# Altium schematic sheet Schematic Prints("All Documents",Physical)
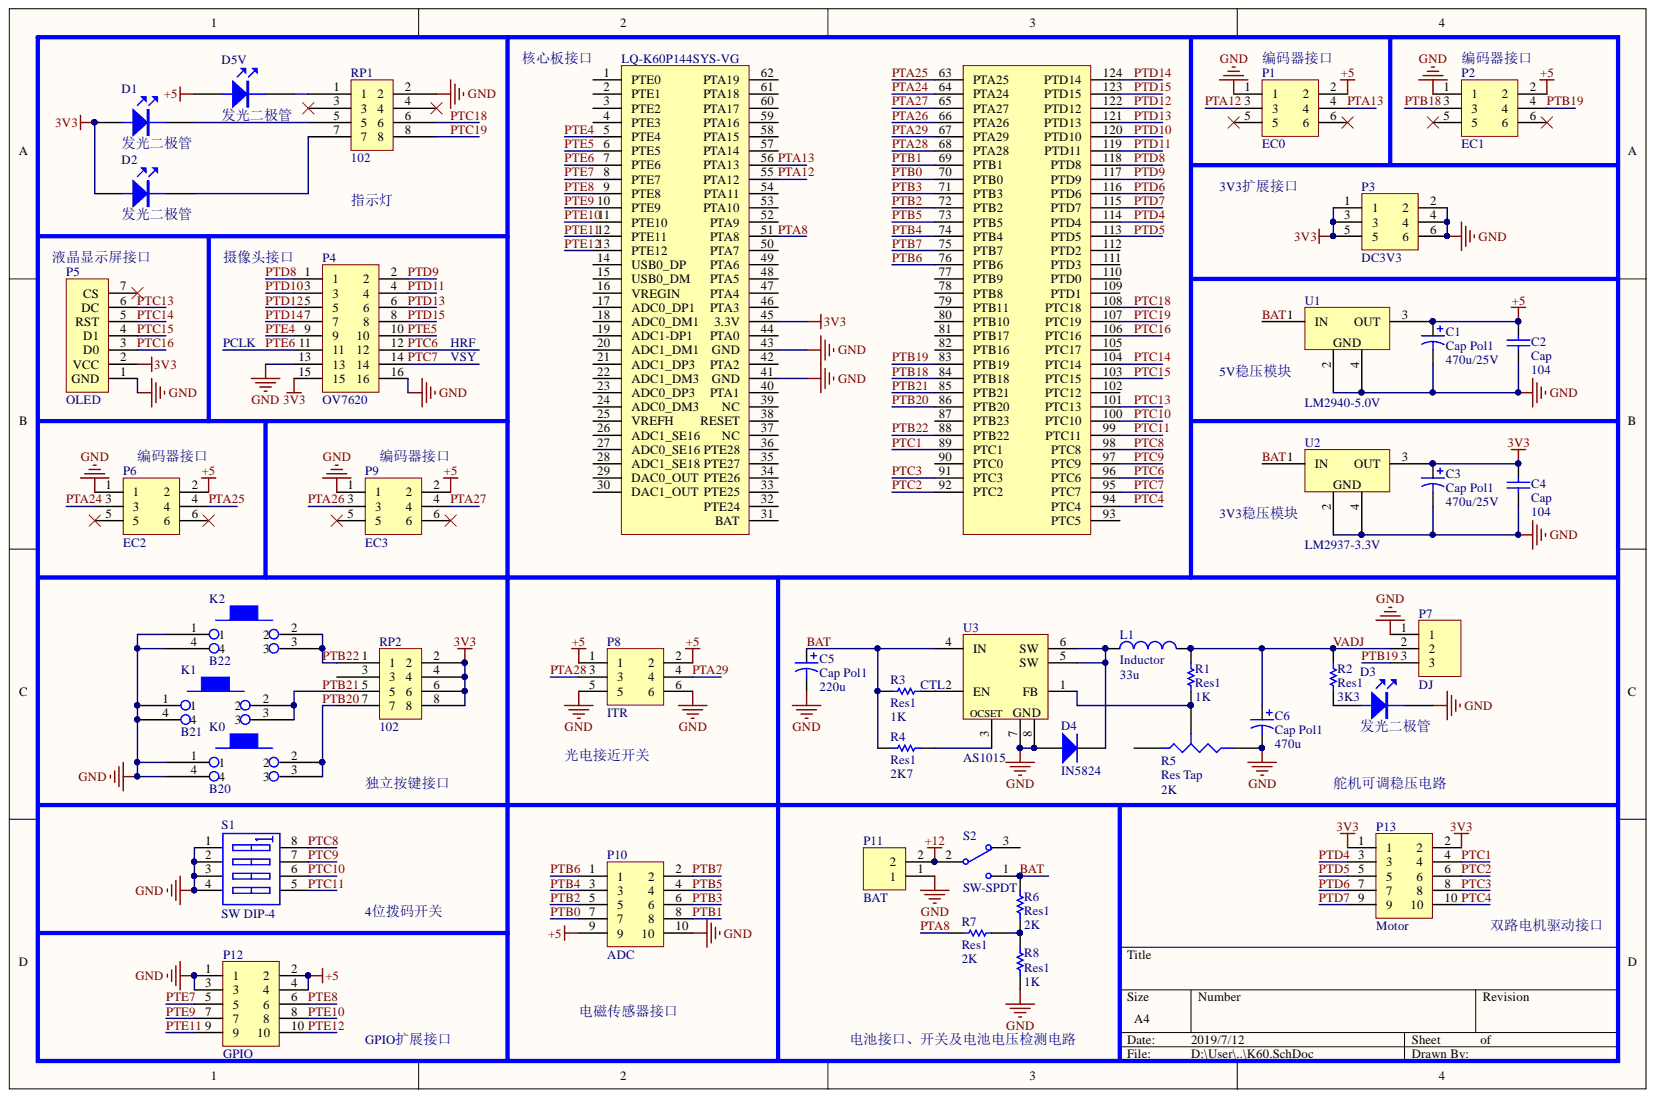
[diagram p0: Schematic Prints("All Documents",Physical)]

## Parts
C1  Cap Pol1  value 470u/25V  [CT 8*7 - C]
C2  Cap  value 104  [0603]
C3  Cap Pol1  value 470u/25V  [CT 8*7 - C]
C4  Cap  value 104  [0603]
C5  Cap Pol1  value 220u  [CT 8*7 - C]
C6  Cap Pol1  value 470u  [CT 8*7 - C]
D1  发光二极管  [0603]
D2  发光二极管  [0603]
D3  发光二极管  [0603]
D4  IN5824  [SMA]
D5V  发光二极管  [0603]
K0  B20  [TSW DIP-6*6*6]
K1  B21  [TSW DIP-6*6*6]
K2  B22  [TSW DIP-6*6*6]
L1  Inductor  value 33u  [CDRH127]
LQ-K60P144SYS-VG  (no value)  [LQ_KXXP144-SYSVG]
P1  EC0  [2.54排针2X3]
P2  EC1  [2.54排针2X3]
P3  DC3V3  [2.54排针2X3]
P4  OV7620  [2.54排针2X8]
P5  OLED  [HDR1X7]
P6  EC2  [2.54排针2X3]
P7  DJ  [2.54排针1X3]
P8  ITR  [2.54排针2X3]
P9  EC3  [2.54排针2X3]
P10  ADC  [2.54排针2X5]
P11  BAT  [KF301-2P]
P12  GPIO  [2.54排针2X5]
P13  Motor  [2.54排针2X5]
R1  Res1  value 1K  [0603]
R2  Res1  value 3K3  [0603]
R3  Res1  value 1K  [0603]
R4  Res1  value 2K7  [0603]
R5  Res Tap  value 2K  [VR_A1]
R6  Res1  value 2K  [0603]
R7  Res1  value 2K  [0603]
R8  Res1  value 1K  [0603]
RP1  102  [8P4R_0603]
RP2  102  [8P4R_0603]
S1  SW DIP-4  [BMKG4]
S2  SW-SPDT  [SW3-H2X3_8.6X4.5_L]
U1  LM2940-5.0V  [TO-263-3]
U2  LM2937-3.3V  [TO-263-3]
U3  AS1015  [NSO8_N]

## Nets
3V3: C3.1 C4.2 D1.1 D2.1 LQ-K60P144SYS-VG.45 P3.1 P3.3 P3.5 P4.15 P5.2(VCC) P13.1 P13.2 RP2.2 RP2.4 RP2.6 RP2.8 U2.3(OUT)
+5: C1.1 C2.2 D5V.1 P1.2 P2.2 P6.2 P8.1 P8.2 P9.2 P10.9 P12.2 P12.4 U1.3(OUT)
+12: P11.2 S2.2
GND: C1.2 C2.1 C3.2 C4.1 C5.2 C6.2 D3.2 D4.1 K0.1 K0.4 K1.1 K1.4 K2.1 K2.4 LQ-K60P144SYS-VG.41 LQ-K60P144SYS-VG.43 P1.1 P2.1 P3.2 P3.4 P3.6 P4.13 P4.16 P5.1(GND) P6.1 P7.1 P8.5 P8.6 P9.1 P10.10 P11.1 P12.1 P12.3 R5.2 R8.1 RP1.2 S1.1 S1.2 S1.3 S1.4 U1.2 U1.4 U2.2 U2.4 U3.7 U3.8
BAT: C5.1 R3.1 R4.1 R6.2 S2.1 U1.1(IN) U2.1(IN) U3.4(IN)
PTA8: LQ-K60P144SYS-VG.51(PTA8) R7.1
PTA12: LQ-K60P144SYS-VG.55 P1.3
PTA13: LQ-K60P144SYS-VG.56 P1.4
PTA24: LQ-K60P144SYS-VG.64 P6.3
PTA25: LQ-K60P144SYS-VG.63 P6.4
PTA26: LQ-K60P144SYS-VG.66 P9.3
PTA27: LQ-K60P144SYS-VG.65 P9.4
PTA28: LQ-K60P144SYS-VG.68 P8.3
PTA29: LQ-K60P144SYS-VG.67(PTA29) P8.4
PTB0: LQ-K60P144SYS-VG.70 P10.7
PTB1: LQ-K60P144SYS-VG.69 P10.8
PTB2: LQ-K60P144SYS-VG.72(PTB2) P10.5
PTB3: LQ-K60P144SYS-VG.71 P10.6
PTB4: LQ-K60P144SYS-VG.74(PTB4) P10.3
PTB5: LQ-K60P144SYS-VG.73 P10.4
PTB6: LQ-K60P144SYS-VG.76 P10.1
PTB7: LQ-K60P144SYS-VG.75 P10.2
PTB18: LQ-K60P144SYS-VG.84 P2.3
PTB19: LQ-K60P144SYS-VG.83 P2.4 P7.3
PTB20: K0.2 K0.3 LQ-K60P144SYS-VG.86 RP2.7
PTB21: K1.2 K1.3 LQ-K60P144SYS-VG.85 RP2.5
PTB22: K2.2 K2.3 LQ-K60P144SYS-VG.88 RP2.1
PTC1: LQ-K60P144SYS-VG.89 P13.4
PTC2: LQ-K60P144SYS-VG.92 P13.6
PTC3: LQ-K60P144SYS-VG.91 P13.8
PTC4: LQ-K60P144SYS-VG.94(PTC4) P13.10
PTC6: LQ-K60P144SYS-VG.96(PTC6) P4.12
PTC7: LQ-K60P144SYS-VG.95(PTC7) P4.14
PTC8: LQ-K60P144SYS-VG.98(PTC8) S1.8
PTC9: LQ-K60P144SYS-VG.97(PTC9) S1.7
PTC10: LQ-K60P144SYS-VG.100(PTC10) S1.6
PTC11: LQ-K60P144SYS-VG.99(PTC11) S1.5
PTC13: LQ-K60P144SYS-VG.101(PTC13) P5.6(DC)
PTC14: LQ-K60P144SYS-VG.104(PTC14) P5.5(RST)
PTC15: LQ-K60P144SYS-VG.103(PTC15) P5.4(D1)
PTC16: LQ-K60P144SYS-VG.106(PTC16) P5.3(D0)
PTC18: LQ-K60P144SYS-VG.108(PTC18) RP1.6
PTC19: LQ-K60P144SYS-VG.107(PTC19) RP1.8
PTD4: LQ-K60P144SYS-VG.114(PTD4) P13.3
PTD5: LQ-K60P144SYS-VG.113(PTD5) P13.5
PTD6: LQ-K60P144SYS-VG.116(PTD6) P13.7
PTD7: LQ-K60P144SYS-VG.115(PTD7) P13.9
PTD8: LQ-K60P144SYS-VG.118(PTD8) P4.1
PTD9: LQ-K60P144SYS-VG.117(PTD9) P4.2
PTD10: LQ-K60P144SYS-VG.120(PTD10) P4.3
PTD11: LQ-K60P144SYS-VG.119(PTD11) P4.4
PTD12: LQ-K60P144SYS-VG.122(PTD12) P4.5
PTD13: LQ-K60P144SYS-VG.121(PTD13) P4.6
PTD14: LQ-K60P144SYS-VG.124(PTD14) P4.7
PTD15: LQ-K60P144SYS-VG.123(PTD15) P4.8
PTE4: LQ-K60P144SYS-VG.5(PTE4) P4.9
PTE5: LQ-K60P144SYS-VG.6(PTE5) P4.10
PTE6: LQ-K60P144SYS-VG.7(PTE6) P4.11
PTE7: LQ-K60P144SYS-VG.8(PTE7) P12.5
PTE8: LQ-K60P144SYS-VG.9(PTE8) P12.6
PTE9: LQ-K60P144SYS-VG.10(PTE9) P12.7
PTE10: LQ-K60P144SYS-VG.11(PTE10) P12.8
PTE11: LQ-K60P144SYS-VG.12(PTE11) P12.9
PTE12: LQ-K60P144SYS-VG.13(PTE12) P12.10
VADJ: C6.1 L1.2 P7.2 R1.2 R2.2
NetD1_2: D1.2 RP1.5
NetD2_2: D2.2 RP1.7
NetD3_1: D3.1 R2.1
NetD4_2: D4.2 L1.1 U3.5(SW) U3.6(SW)
NetD5V_2: D5V.2 RP1.1
NetLQ-K60P144SYS-VG_1: LQ-K60P144SYS-VG.1(PTE0)
NetLQ-K60P144SYS-VG_2: LQ-K60P144SYS-VG.2(PTE1)
NetLQ-K60P144SYS-VG_3: LQ-K60P144SYS-VG.3(PTE2)
NetLQ-K60P144SYS-VG_4: LQ-K60P144SYS-VG.4(PTE3)
NetLQ-K60P144SYS-VG_14: LQ-K60P144SYS-VG.14(USB0_DP)
NetLQ-K60P144SYS-VG_15: LQ-K60P144SYS-VG.15(USB0_DM)
NetLQ-K60P144SYS-VG_16: LQ-K60P144SYS-VG.16(VREGIN)
NetLQ-K60P144SYS-VG_17: LQ-K60P144SYS-VG.17(ADC0_DP1)
NetLQ-K60P144SYS-VG_18: LQ-K60P144SYS-VG.18(ADC0_DM1)
NetLQ-K60P144SYS-VG_19: LQ-K60P144SYS-VG.19(ADC1-DP1)
NetLQ-K60P144SYS-VG_20: LQ-K60P144SYS-VG.20(ADC1_DM1)
NetLQ-K60P144SYS-VG_21: LQ-K60P144SYS-VG.21(ADC1_DP3)
NetLQ-K60P144SYS-VG_22: LQ-K60P144SYS-VG.22(ADC1_DM3)
NetLQ-K60P144SYS-VG_23: LQ-K60P144SYS-VG.23(ADC0_DP3)
NetLQ-K60P144SYS-VG_24: LQ-K60P144SYS-VG.24(ADC0_DM3)
NetLQ-K60P144SYS-VG_25: LQ-K60P144SYS-VG.25(VREFH)
NetLQ-K60P144SYS-VG_26: LQ-K60P144SYS-VG.26(ADC1_SE16)
NetLQ-K60P144SYS-VG_27: LQ-K60P144SYS-VG.27(ADC0_SE16_PTE28)
NetLQ-K60P144SYS-VG_28: LQ-K60P144SYS-VG.28(ADC1_SE18_PTE27)
NetLQ-K60P144SYS-VG_29: LQ-K60P144SYS-VG.29(DAC0_OUT)
NetLQ-K60P144SYS-VG_30: LQ-K60P144SYS-VG.30(DAC1_OUT)
NetLQ-K60P144SYS-VG_31: LQ-K60P144SYS-VG.31
NetLQ-K60P144SYS-VG_32: LQ-K60P144SYS-VG.32
NetLQ-K60P144SYS-VG_33: LQ-K60P144SYS-VG.33
NetLQ-K60P144SYS-VG_34: LQ-K60P144SYS-VG.34
NetLQ-K60P144SYS-VG_35: LQ-K60P144SYS-VG.35
NetLQ-K60P144SYS-VG_36: LQ-K60P144SYS-VG.36
NetLQ-K60P144SYS-VG_37: LQ-K60P144SYS-VG.37
NetLQ-K60P144SYS-VG_38: LQ-K60P144SYS-VG.38
NetLQ-K60P144SYS-VG_39: LQ-K60P144SYS-VG.39
NetLQ-K60P144SYS-VG_40: LQ-K60P144SYS-VG.40
NetLQ-K60P144SYS-VG_42: LQ-K60P144SYS-VG.42
NetLQ-K60P144SYS-VG_44: LQ-K60P144SYS-VG.44
NetLQ-K60P144SYS-VG_46: LQ-K60P144SYS-VG.46
NetLQ-K60P144SYS-VG_47: LQ-K60P144SYS-VG.47
NetLQ-K60P144SYS-VG_48: LQ-K60P144SYS-VG.48
NetLQ-K60P144SYS-VG_49: LQ-K60P144SYS-VG.49
NetLQ-K60P144SYS-VG_50: LQ-K60P144SYS-VG.50
NetLQ-K60P144SYS-VG_52: LQ-K60P144SYS-VG.52
NetLQ-K60P144SYS-VG_53: LQ-K60P144SYS-VG.53
NetLQ-K60P144SYS-VG_54: LQ-K60P144SYS-VG.54
NetLQ-K60P144SYS-VG_57: LQ-K60P144SYS-VG.57
NetLQ-K60P144SYS-VG_58: LQ-K60P144SYS-VG.58
NetLQ-K60P144SYS-VG_59: LQ-K60P144SYS-VG.59
NetLQ-K60P144SYS-VG_60: LQ-K60P144SYS-VG.60
NetLQ-K60P144SYS-VG_61: LQ-K60P144SYS-VG.61
NetLQ-K60P144SYS-VG_62: LQ-K60P144SYS-VG.62
NetLQ-K60P144SYS-VG_77: LQ-K60P144SYS-VG.77
NetLQ-K60P144SYS-VG_78: LQ-K60P144SYS-VG.78
NetLQ-K60P144SYS-VG_79: LQ-K60P144SYS-VG.79
NetLQ-K60P144SYS-VG_80: LQ-K60P144SYS-VG.80
NetLQ-K60P144SYS-VG_81: LQ-K60P144SYS-VG.81
NetLQ-K60P144SYS-VG_82: LQ-K60P144SYS-VG.82
NetLQ-K60P144SYS-VG_87: LQ-K60P144SYS-VG.87
NetLQ-K60P144SYS-VG_90: LQ-K60P144SYS-VG.90
NetLQ-K60P144SYS-VG_93: LQ-K60P144SYS-VG.93(PTC5)
NetLQ-K60P144SYS-VG_102: LQ-K60P144SYS-VG.102(PTC12)
NetLQ-K60P144SYS-VG_105: LQ-K60P144SYS-VG.105(PTC17)
NetLQ-K60P144SYS-VG_109: LQ-K60P144SYS-VG.109(PTD1)
NetLQ-K60P144SYS-VG_110: LQ-K60P144SYS-VG.110(PTD0)
NetLQ-K60P144SYS-VG_111: LQ-K60P144SYS-VG.111(PTD3)
NetLQ-K60P144SYS-VG_112: LQ-K60P144SYS-VG.112(PTD2)
NetP1_5: P1.5
NetP1_6: P1.6
NetP2_5: P2.5
NetP2_6: P2.6
NetP5_7: P5.7(CS)
NetP6_5: P6.5
NetP6_6: P6.6
NetP9_5: P9.5
NetP9_6: P9.6
NetR1_1: R1.1 R5.3 U3.1(FB)
NetR3_2: R3.2 U3.2(EN)
NetR4_2: R4.2 U3.3
NetR5_1: R5.1
NetR6_1: R6.1 R7.2 R8.2
NetRP1_3: RP1.3
NetRP1_4: RP1.4
NetRP2_3: RP2.3
NetS2_3: S2.3

## Library data of the parts
C1 C3 C5 C6 = Cap Pol1: Polarized Capacitor (Radial); Simulation: CAP; Signal Integrity: Cap Pol; Published: 8-Jun-2000; PackageReference: RB7.6-15; PackageDescription: Polarized Capacitor (Radial); 2 Leads; Library Name: Miscellaneous Devices.IntLib; LatestRevisionNote: Re-released for DXP Platform.; LatestRevisionDate: 17-Jul-2002; Component Kind: Standard; Publisher: Altium Limited
C2 C4 = Cap: Capacitor; Simulation: CAP; Signal Integrity: Cap; Published: 8-Jun-2000; PackageReference: RAD-0.3; PackageDescription: Radial Cap, Thru-Hole; 2 Leads; 0.3 in Pin Spacing; Library Name: Miscellaneous Devices.IntLib; LatestRevisionNote: Re-released for DXP Platform.; LatestRevisionDate: 17-Jul-2002; Component Kind: Standard; Publisher: Altium Limited
D4 = Diode: SS54; Signal Integrity: Diode; Published: 8-Jun-2000; PackageVersion: Sep-1996; PackageReference: SMC; PackageDescription: DO-214-AB/SMC; 2 C-Bend Leads; Body 7.9 x 5.9 mm, inc. leads (LxW); Library Name: Miscellaneous Devices.IntLib; LatestRevisionNote: Re-released for DXP Platform.; LatestRevisionDate: 17-Jul-2002; Component Kind: Standard; Code_JEDEC: DO-214-AB; Publisher: Altium Limited
K0-K2 = key: Component Kind: Standard; Library Name: LQ_KXXP144-SYSVGSCH.SCHLIB
L1 = Inductor: Code_IEC: 1005; Simulation: INDUCTOR; Signal Integrity: Inductor; Published: 8-Jun-2000; PackageReference: 0402-A; PackageDescription: Chip Inductor; Library Name: Miscellaneous Devices.IntLib; LatestRevisionNote: IPC-7351 Footprint Added.; LatestRevisionDate: 29-May-2009; Description: Inductor; Component Kind: Standard; Code_JEITA: 0402; Publisher: Altium Limited
LQ-K60P144SYS-VG = LQ_KXXP144-SYSVG: Component Kind: Standard; Library Name: LQ_KXXP144-SYSVGSCH.SCHLIB
P1-P3 P6 P8 P9 = Header 3X2: Header, 3-Pin, Dual row; Signal Integrity: Connector; LatestRevisionNote: Re-released for DXP Platform.; LatestRevisionDate: 17-Jul-2002; Component Kind: Standard; Publisher: Altium Limited
  P1-P3 P6 P9: Library Name: Miscellaneous Connectors.IntLib
  P8: Library Name: K60.IntLib
P4 = Header 8X2: Header, 8-Pin, Dual row; Signal Integrity: Connector; Library Name: Miscellaneous Connectors.IntLib; LatestRevisionNote: Re-released for DXP Platform.; LatestRevisionDate: 17-Jul-2002; Component Kind: Standard; Publisher: Altium Limited
P5 = Header 7: Header, 7-Pin; Signal Integrity: Connector; Library Name: Miscellaneous Connectors.IntLib; LatestRevisionNote: Re-released for DXP Platform.; LatestRevisionDate: 17-Jul-2002; Component Kind: Standard; Publisher: Altium Limited
P7 = Header 3: Header, 3-Pin; Signal Integrity: Connector; Library Name: Miscellaneous Connectors.IntLib; LatestRevisionNote: Re-released for DXP Platform.; LatestRevisionDate: 17-Jul-2002; Component Kind: Standard; Publisher: Altium Limited
P10 P12 P13 = Header 5X2: Header, 5-Pin, Dual row; Signal Integrity: Connector; LatestRevisionNote: Re-released for DXP Platform.; LatestRevisionDate: 17-Jul-2002; Component Kind: Standard; Publisher: Altium Limited
  P10 P12: Library Name: Miscellaneous Connectors.IntLib
  P13: Library Name: K60.IntLib
P11 = Header 2: Header, 2-Pin; Signal Integrity: Connector; Library Name: Miscellaneous Connectors.IntLib; LatestRevisionNote: Re-released for DXP Platform.; LatestRevisionDate: 17-Jul-2002; Component Kind: Standard; Publisher: Altium Limited
R1 R2 R4 R6-R8 = Res1: Resistor; Simulation: RESISTOR; Signal Integrity: Res; Published: 8-Jun-2000; PackageReference: AXIAL-0.3; PackageDescription: Axial Device, Thru-Hole; 2 Leads; 0.3 in Pin Spacing; Library Name: Miscellaneous Devices.IntLib; LatestRevisionNote: Re-released for DXP Platform.; LatestRevisionDate: 17-Jul-2002; Component Kind: Standard; Publisher: Altium Limited
R3 = Res1: Resistor; Value: 1K; Simulation: RESISTOR; Signal Integrity: Res; Published: 8-Jun-2000; PackageReference: AXIAL-0.3; PackageDescription: Axial Device, Thru-Hole; 2 Leads; 0.3 in Pin Spacing; Library Name: Miscellaneous Devices.IntLib; LatestRevisionNote: Re-released for DXP Platform.; LatestRevisionDate: 17-Jul-2002; Component Kind: Standard; Publisher: Altium Limited
R5 = Res Tap: Tapped Resistor; Sim Note: Enter the Resistance value and the set point into parameter fields.; Set Position: 0.5; Simulation: POT; Signal Integrity: Res Tap; Published: 24-Mar-1999; PackageReference: VR3; PackageDescription: Variable Resistor, Thru-Hole; 3 Leads; Library Name: Miscellaneous Devices.IntLib; LatestRevisionNote: Re-released for DXP Platform.; LatestRevisionDate: 17-Jul-2002; Component Kind: Standard; Publisher: Altium Limited
RP1 RP2 = Res Pak-8: Library Name: K60.SCHLIB; Component Kind: Standard
S1 = SW DIP-4: DIP Switch, 4 Position, SPST; Simulation: dpsw4; Published: 24-Mar-1999; PackageVersion: Dec-2002; PackageReference: SO8; PackageDescription: 8-Pin Small Outline Integrated Circuit 1.27 mm Pitch; Library Name: Miscellaneous Devices.IntLib; LatestRevisionNote: IPC-7351 Footprint Added.; LatestRevisionDate: 29-May-2009; Component Kind: Standard; Code_JEDEC: MS-012-AA; Code_IPC: SOIC127P600-8; Publisher: Altium Limited
S2 = SW-SPDT: SPDT Subminiature Toggle Switch, Right Angle Mounting, Vertical Actuation; Published: 24-Mar-1999; PackageReference: TL36WW15050; PackageDescription: Switch; 4 Leads; Library Name: Miscellaneous Devices.IntLib; LatestRevisionNote: Schematic revised, PCB Footprint replaced.; LatestRevisionDate: 17-Dec-2003; DatasheetVersion: 05-Dec-2000; ComponentLink1URL: http://www.apem.com; ComponentLink1Description: Manufacturer Link; Component Kind: Standard; Publisher: Altium Limited
U1 U2 = LM2940: 5V稳压芯片; Component Kind: Standard; Library Name: Fszhang.IntLib
U3 = AS1015: 可调升压芯片; Component Kind: Standard; Library Name: Fszhang.IntLib
D1-D3 D5V = 发光二极管: Library Name: K60.IntLib; Component Kind: Standard
